# Altium schematic sheet FMC_LPC_MIPI.SchDoc(FMC_LPC_MIPI)
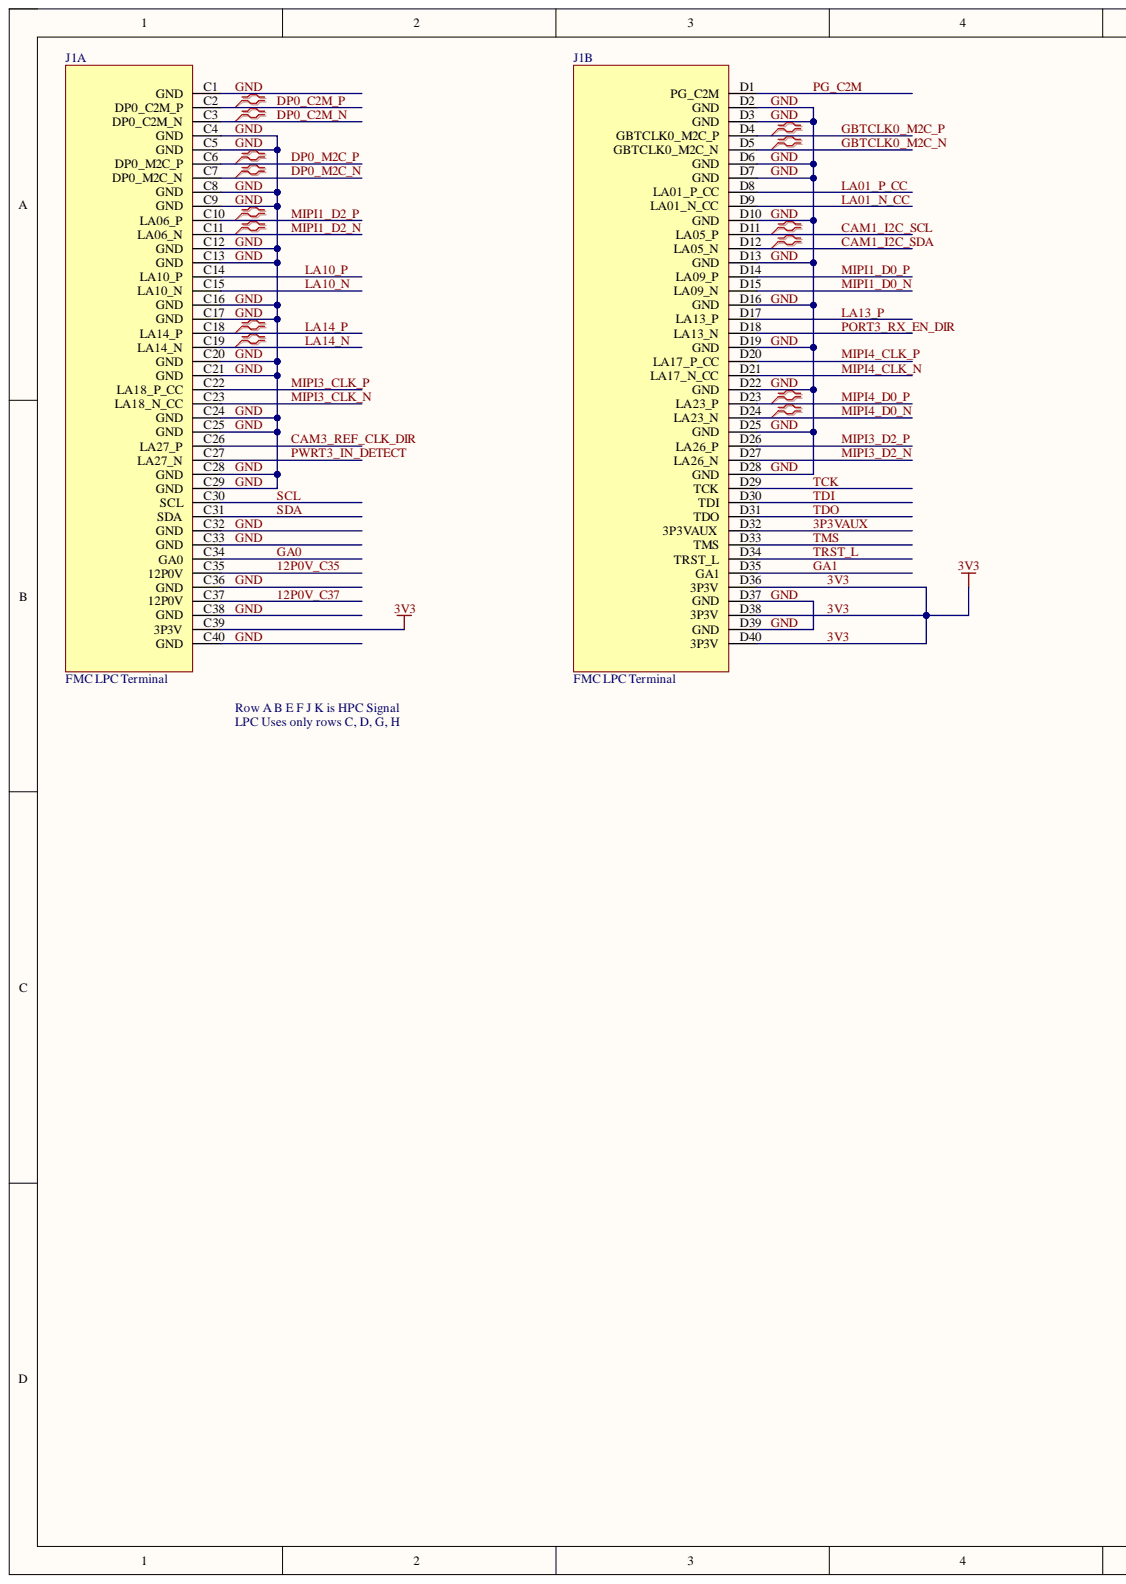
[diagram p0: FMC_LPC_MIPI.SchDoc, left]
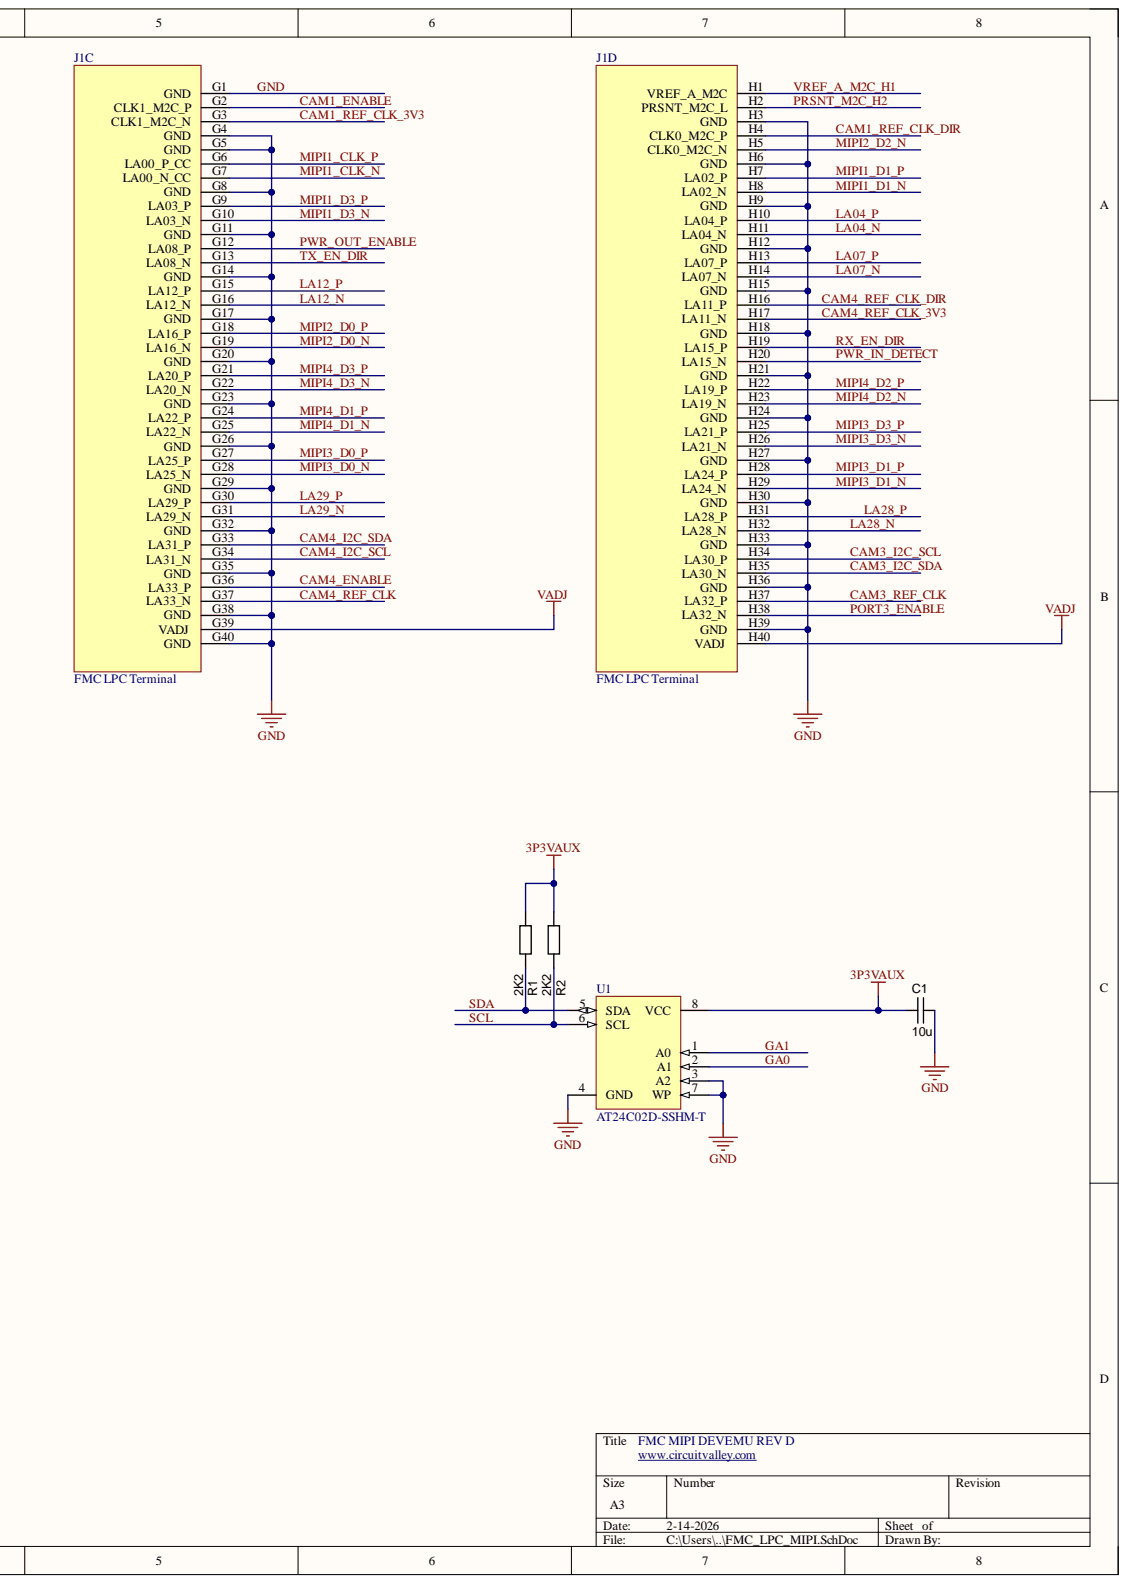
[diagram p1: FMC_LPC_MIPI.SchDoc, right]

## Parts
C1  value 10u  [CAPC1608N]  (hidden comment: approved sub OK)
J1  FMC LPC Terminal  [SAMTEC_ASP-134604-01]  (parts A,B,C,D)
R1  value 2K2  [RESC1005N]  (hidden comment: Thick Film)
R2  value 2K2  [RESC1005N]  (hidden comment: Thick Film)
U1  AT24C02D-SSHM-T  [SOIC8]

## Nets
VADJ: J1.G39(VADJ) J1.H40(VADJ)
3P3VAUX: C1.1 J1.D32(3P3VAUX) R1.1 R2.1 U1.8(VCC)
3V3: J1.C39(3P3V) J1.D36(3P3V) J1.D38(3P3V) J1.D40(3P3V)
12P0V_C35: J1.C35(12P0V)
12P0V_C37: J1.C37(12P0V)
CAM1_ENABLE: J1.G2(CLK1_M2C_P)
CAM1_I2C_SCL: J1.D11(LA05_P)
CAM1_I2C_SDA: J1.D12(LA05_N)
CAM1_REF_CLK_3V3: J1.G3(CLK1_M2C_N)
CAM1_REF_CLK_DIR: J1.H4(CLK0_M2C_P)
CAM3_I2C_SCL: J1.H34(LA30_P)
CAM3_I2C_SDA: J1.H35(LA30_N)
CAM3_REF_CLK: J1.H37(LA32_P)
CAM3_REF_CLK_DIR: J1.C26(LA27_P)
CAM4_ENABLE: J1.G36(LA33_P)
CAM4_I2C_SCL: J1.G34(LA31_N)
CAM4_I2C_SDA: J1.G33(LA31_P)
CAM4_REF_CLK: J1.G37(LA33_N)
CAM4_REF_CLK_3V3: J1.H17(LA11_N)
CAM4_REF_CLK_DIR: J1.H16(LA11_P)
DP0_C2M_N: J1.C3(DP0_C2M_N)
DP0_C2M_P: J1.C2(DP0_C2M_P)
DP0_M2C_N: J1.C7(DP0_M2C_N)
DP0_M2C_P: J1.C6(DP0_M2C_P)
GA0: J1.C34(GA0) U1.2(A1)
GA1: J1.D35(GA1) U1.1(A0)
GBTCLK0_M2C_N: J1.D5(GBTCLK0_M2C_N)
GBTCLK0_M2C_P: J1.D4(GBTCLK0_M2C_P)
GND: C1.2 J1.C1(GND) J1.C4(GND) J1.C5(GND) J1.C8(GND) J1.C9(GND) J1.C12(GND) J1.C13(GND) J1.C16(GND) J1.C17(GND) J1.C20(GND) J1.C21(GND) J1.C24(GND) J1.C25(GND) J1.C28(GND) J1.C29(GND) J1.C32(GND) J1.C33(GND) J1.C36(GND) J1.C38(GND) J1.C40(GND) J1.D2(GND) J1.D3(GND) J1.D6(GND) J1.D7(GND) J1.D10(GND) J1.D13(GND) J1.D16(GND) J1.D19(GND) J1.D22(GND) J1.D25(GND) J1.D28(GND) J1.D37(GND) J1.D39(GND) J1.G1(GND) J1.G4(GND) J1.G5(GND) J1.G8(GND) J1.G11(GND) J1.G14(GND) J1.G17(GND) J1.G20(GND) J1.G23(GND) J1.G26(GND) J1.G29(GND) J1.G32(GND) J1.G35(GND) J1.G38(GND) J1.G40(GND) J1.H3(GND) J1.H6(GND) J1.H9(GND) J1.H12(GND) J1.H15(GND) J1.H18(GND) J1.H21(GND) J1.H24(GND) J1.H27(GND) J1.H30(GND) J1.H33(GND) J1.H36(GND) J1.H39(GND) U1.3(A2) U1.4(GND) U1.7(WP)
LA01_N_CC: J1.D9(LA01_N_CC)
LA01_P_CC: J1.D8(LA01_P_CC)
LA04_N: J1.H11(LA04_N)
LA04_P: J1.H10(LA04_P)
LA07_N: J1.H14(LA07_N)
LA07_P: J1.H13(LA07_P)
LA10_N: J1.C15(LA10_N)
LA10_P: J1.C14(LA10_P)
LA12_N: J1.G16(LA12_N)
LA12_P: J1.G15(LA12_P)
LA13_P: J1.D17(LA13_P)
LA14_N: J1.C19(LA14_N)
LA14_P: J1.C18(LA14_P)
LA28_N: J1.H32(LA28_N)
LA28_P: J1.H31(LA28_P)
LA29_N: J1.G31(LA29_N)
LA29_P: J1.G30(LA29_P)
MIPI1_CLK_N: J1.G7(LA00_N_CC)
MIPI1_CLK_P: J1.G6(LA00_P_CC)
MIPI1_D0_N: J1.D15(LA09_N)
MIPI1_D0_P: J1.D14(LA09_P)
MIPI1_D1_N: J1.H8(LA02_N)
MIPI1_D1_P: J1.H7(LA02_P)
MIPI1_D2_N: J1.C11(LA06_N)
MIPI1_D2_P: J1.C10(LA06_P)
MIPI1_D3_N: J1.G10(LA03_N)
MIPI1_D3_P: J1.G9(LA03_P)
MIPI2_D0_N: J1.G19(LA16_N)
MIPI2_D0_P: J1.G18(LA16_P)
MIPI2_D2_N: J1.H5(CLK0_M2C_N)
MIPI3_CLK_N: J1.C23(LA18_N_CC)
MIPI3_CLK_P: J1.C22(LA18_P_CC)
MIPI3_D0_N: J1.G28(LA25_N)
MIPI3_D0_P: J1.G27(LA25_P)
MIPI3_D1_N: J1.H29(LA24_N)
MIPI3_D1_P: J1.H28(LA24_P)
MIPI3_D2_N: J1.D27(LA26_N)
MIPI3_D2_P: J1.D26(LA26_P)
MIPI3_D3_N: J1.H26(LA21_N)
MIPI3_D3_P: J1.H25(LA21_P)
MIPI4_CLK_N: J1.D21(LA17_N_CC)
MIPI4_CLK_P: J1.D20(LA17_P_CC)
MIPI4_D0_N: J1.D24(LA23_N)
MIPI4_D0_P: J1.D23(LA23_P)
MIPI4_D1_N: J1.G25(LA22_N)
MIPI4_D1_P: J1.G24(LA22_P)
MIPI4_D2_N: J1.H23(LA19_N)
MIPI4_D2_P: J1.H22(LA19_P)
MIPI4_D3_N: J1.G22(LA20_N)
MIPI4_D3_P: J1.G21(LA20_P)
PG_C2M: J1.D1(PG_C2M)
PORT3_ENABLE: J1.H38(LA32_N)
PORT3_RX_EN_DIR: J1.D18(LA13_N)
PRSNT_M2C_H2: J1.H2(PRSNT_M2C_L)
PWR_IN_DETECT: J1.H20(LA15_N)
PWR_OUT_ENABLE: J1.G12(LA08_P)
PWRT3_IN_DETECT: J1.C27(LA27_N)
RX_EN_DIR: J1.H19(LA15_P)
SCL: J1.C30(SCL) R2.2 U1.6(SCL)
SDA: J1.C31(SDA) R1.2 U1.5(SDA)
TCK: J1.D29(TCK)
TDI: J1.D30(TDI)
TDO: J1.D31(TDO)
TMS: J1.D33(TMS)
TRST_L: J1.D34(TRST_L)
TX_EN_DIR: J1.G13(LA08_N)
VREF_A_M2C_H1: J1.H1(VREF_A_M2C)

## Library data of the parts
C1 = CAPC_560p_0603_5%: Capacitor SMD Cer 560pF 5% 50V NP0 0603; Manufacturer 1: AVX Corporation; Manufacturer Part Number 1: 06035A561JAT2A; Capacitance: 470pF; Material: ceramic; Ratings: 50V; NP0; Applications: General Purpose; Component Kind: Standard; ComponentLink1Description: http://www.avx.com/docs/Catalogs/ccog.pdf; ComponentLink1URL: http://www.avx.com/docs/Catalogs/ccog.pdf; Height - Seated (Max): -; Lead Spacing: -; Lead Style: -; Library Name: PBL - Capacitors.SCHLIB; Mounting Type: Surface Mount, MLCC; Operating Temperature: -55°C ~ 125°C; Package / Case: 0603 (1608 metric); Size / Dimension: 0.063" L x 0.032" W (1.60mm x 0.81mm); Supplier 1: Digi-Key; Supplier Part Number 1: 478-1184-1-ND; Thickness (Max): 0.035" (0.90mm); Voltage - Rated: 50V
J1 = FMC_LPC_TERMINAL: FMC LPC Connector Terminal ASP-134604-01; Component Kind: Standard; Library Name: cvlib.SchLib
R1 R2 = RES_3K3_0402_5%: Resistor SMD chip 3.3k Ohm 0.063W 5% 0402; Manufacturer 1: Yageo; Manufacturer Part Number 1: RC0402JR-073K3L; Ratings: 1/16 W; Component Kind: Standard; ComponentLink1Description: http://www.yageo.com/documents/recent/PYu-RC0402_51_RoHS_L_6.pdf; ComponentLink1URL: http://www.yageo.com/documents/recent/PYu-RC0402_51_RoHS_L_6.pdf; Library Name: PBL - Resistors.SCHLIB; Material: Thick Film; Package / Case: 0402 (1005 metric); Supplier 1: Digi-Key; Supplier Part Number 1: 311-3.3KJRCT-ND; Tolerance: ±5%
U1 = AT24C02D-SSHM-T: 2Kbit I2C EEPROM; Component Kind: Standard; Library Name: cvlib.SchLib
